# Altium schematic sheet remote Reciever_110V.SchDoc
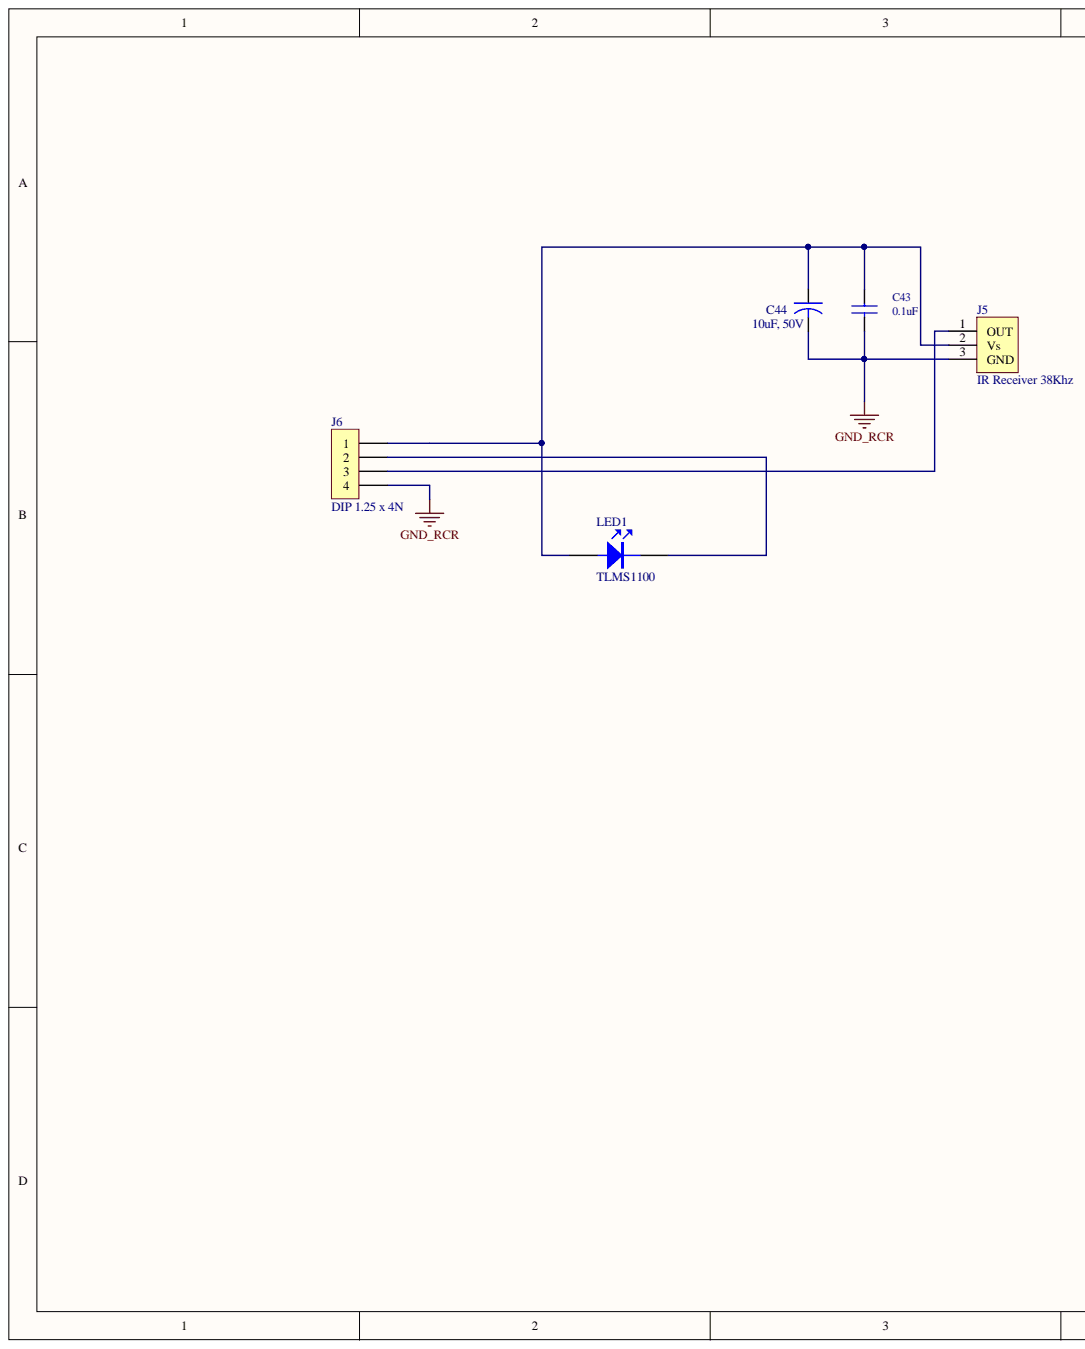
[diagram p0: remote Reciever_110V.SchDoc, left]
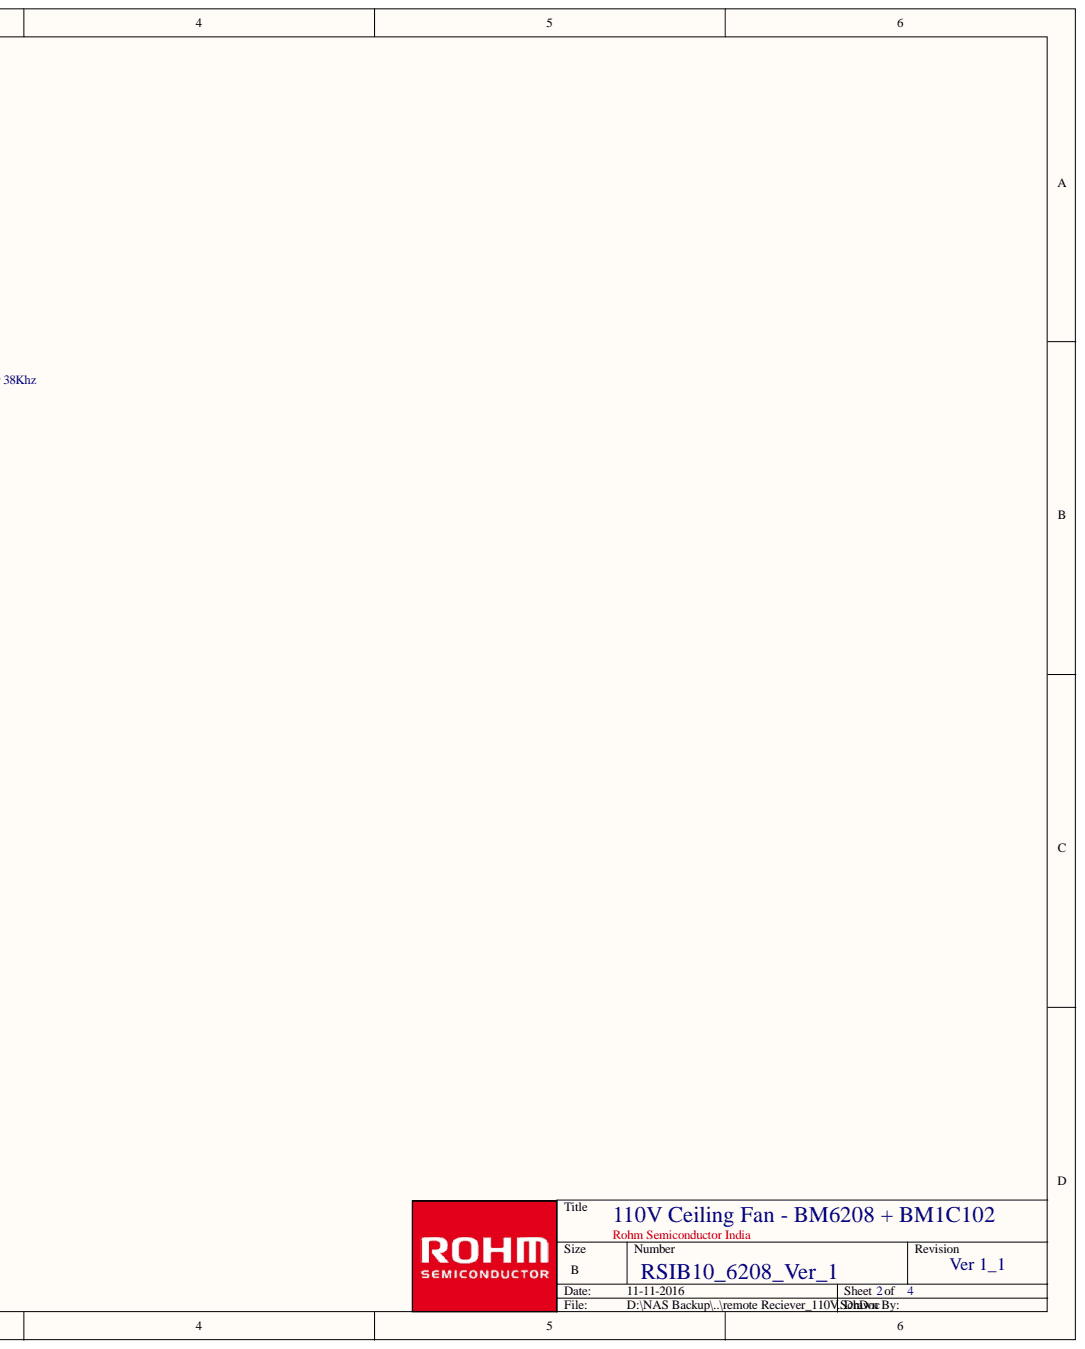
[diagram p1: remote Reciever_110V.SchDoc, right]

## Parts
C43  0.1uF  [C0805]
C44  10uF, 50V  Voltage: 50V  [CAP_M_5/2]
J5  IR Receiver 38Khz  Section: Receiver  Type: IR Receiver  [CON_3X1 - IRSENSE]
J6  DIP 1.25 x 4N  [CON_2X4]
LED1  TLMS1100  [SMA/1_2]

## Nets
GND_RCR: C43.2 C44.2 J5.3 J6.4
NetC43_1: C43.1 C44.1 J5.2 J6.1 LED1.1
NetJ5_1: J5.1 J6.3
NetJ6_2: J6.2 LED1.2

## Library data of the parts
C43 = CAP NP: Section: Receiver; Type: SMD_Cap; Value: 0.004615; Component Kind: Standard; Supplier 1: *Wurth; Supplier Part Number 1: 885 012 207 072; Library Name: Altium_Ara_Sch_libraries_R1.SchLib
C44 = Cap TH 5/2: CAP ALUM 10UF 20% 50V RADIAL; Section: Receiver; Type: Electrolytic; Value: 0.023; Component Kind: Standard; Supplier 1: *Samwha; Supplier Part Number 1: SD1H106M05011PC; Library Name: Altium_Ara_Sch_libraries_R1.SchLib
J5 = TSOP2138: Value: 0.215; Component Kind: Standard; Description: IR Receiver 38Khz; Supplier 1: *Rohm; Supplier Part Number 1: RPM7138-V4R; Library Name: Altium_Ara_Sch_libraries_R1.SchLib
J6 = CON4: CONN SOCKET HOUSING 4POS 1.25MM; Section: Receiver; Value: .05; Component Kind: Standard; Supplier 1: Hirose; Supplier Part Number 1: DF13-4S-1.25C; Type: Connector; Library Name: Altium_Ara_Sch_libraries_R1.SchLib
LED1 = LED: Value: 1; Component Kind: Standard; Library Name: Altium_Ara_Sch_libraries_R1.SchLib
